# Altium schematic sheet IR&control.SchDoc(IR&control)
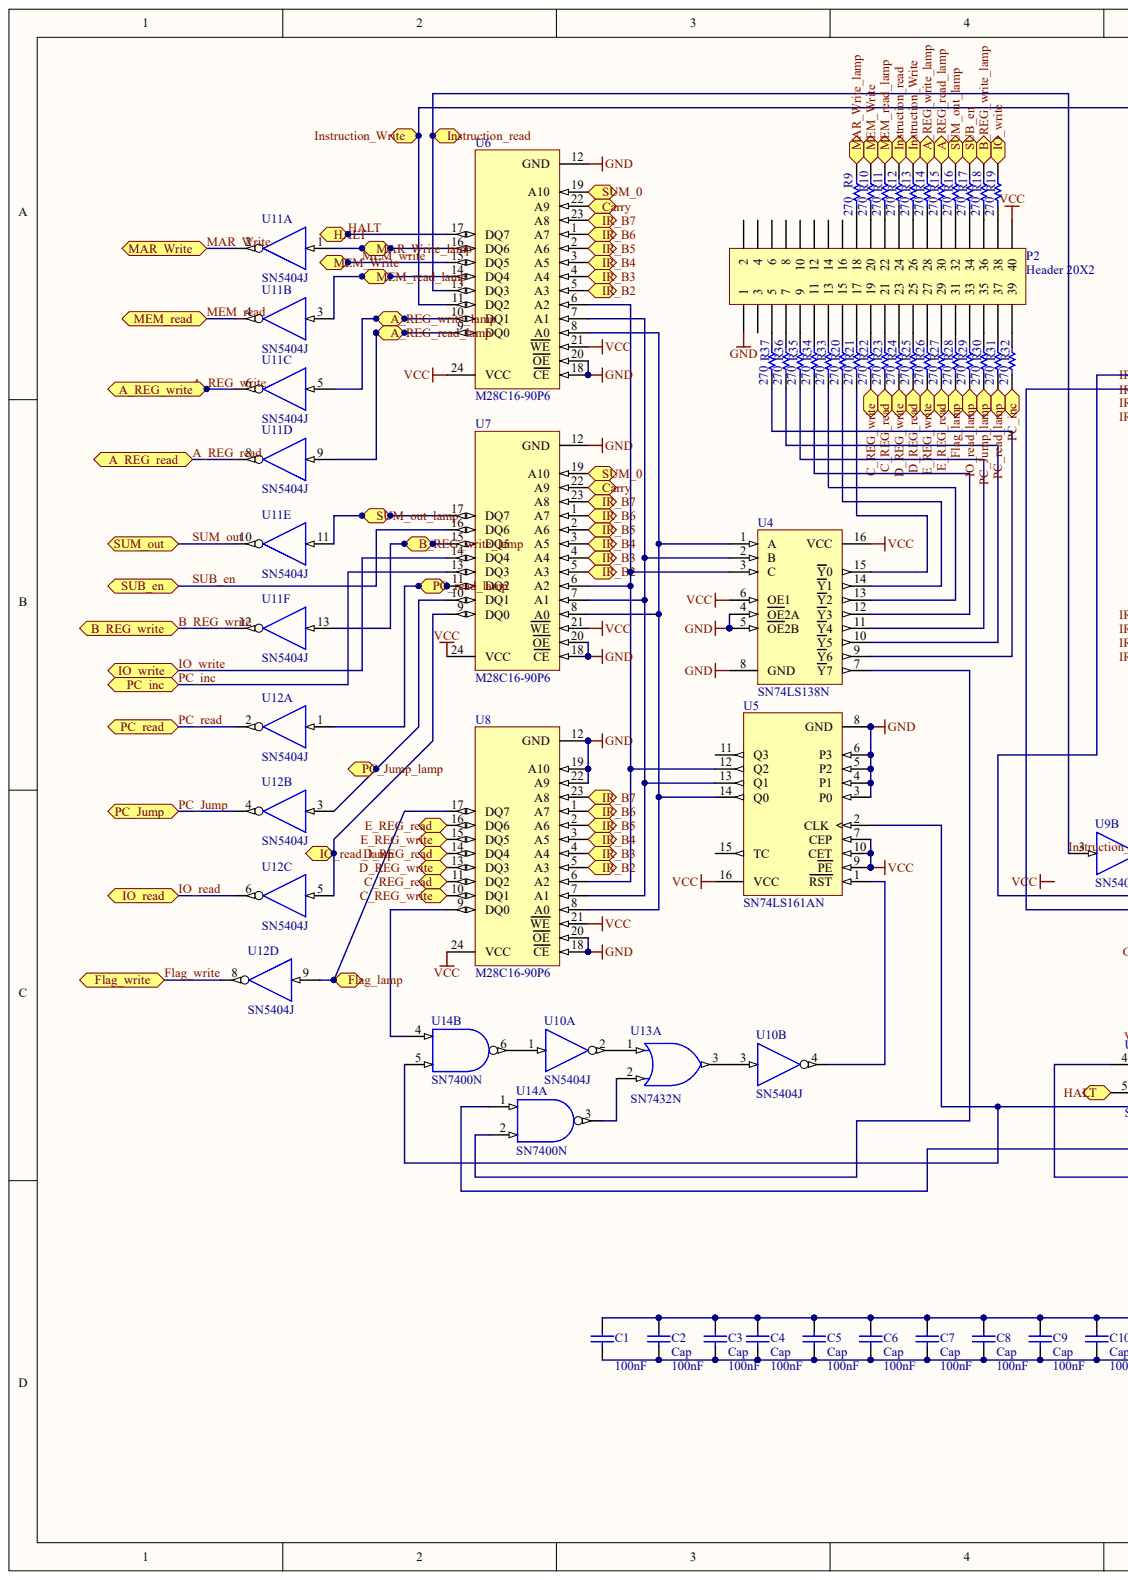
[diagram p0: IR&control.SchDoc, left]
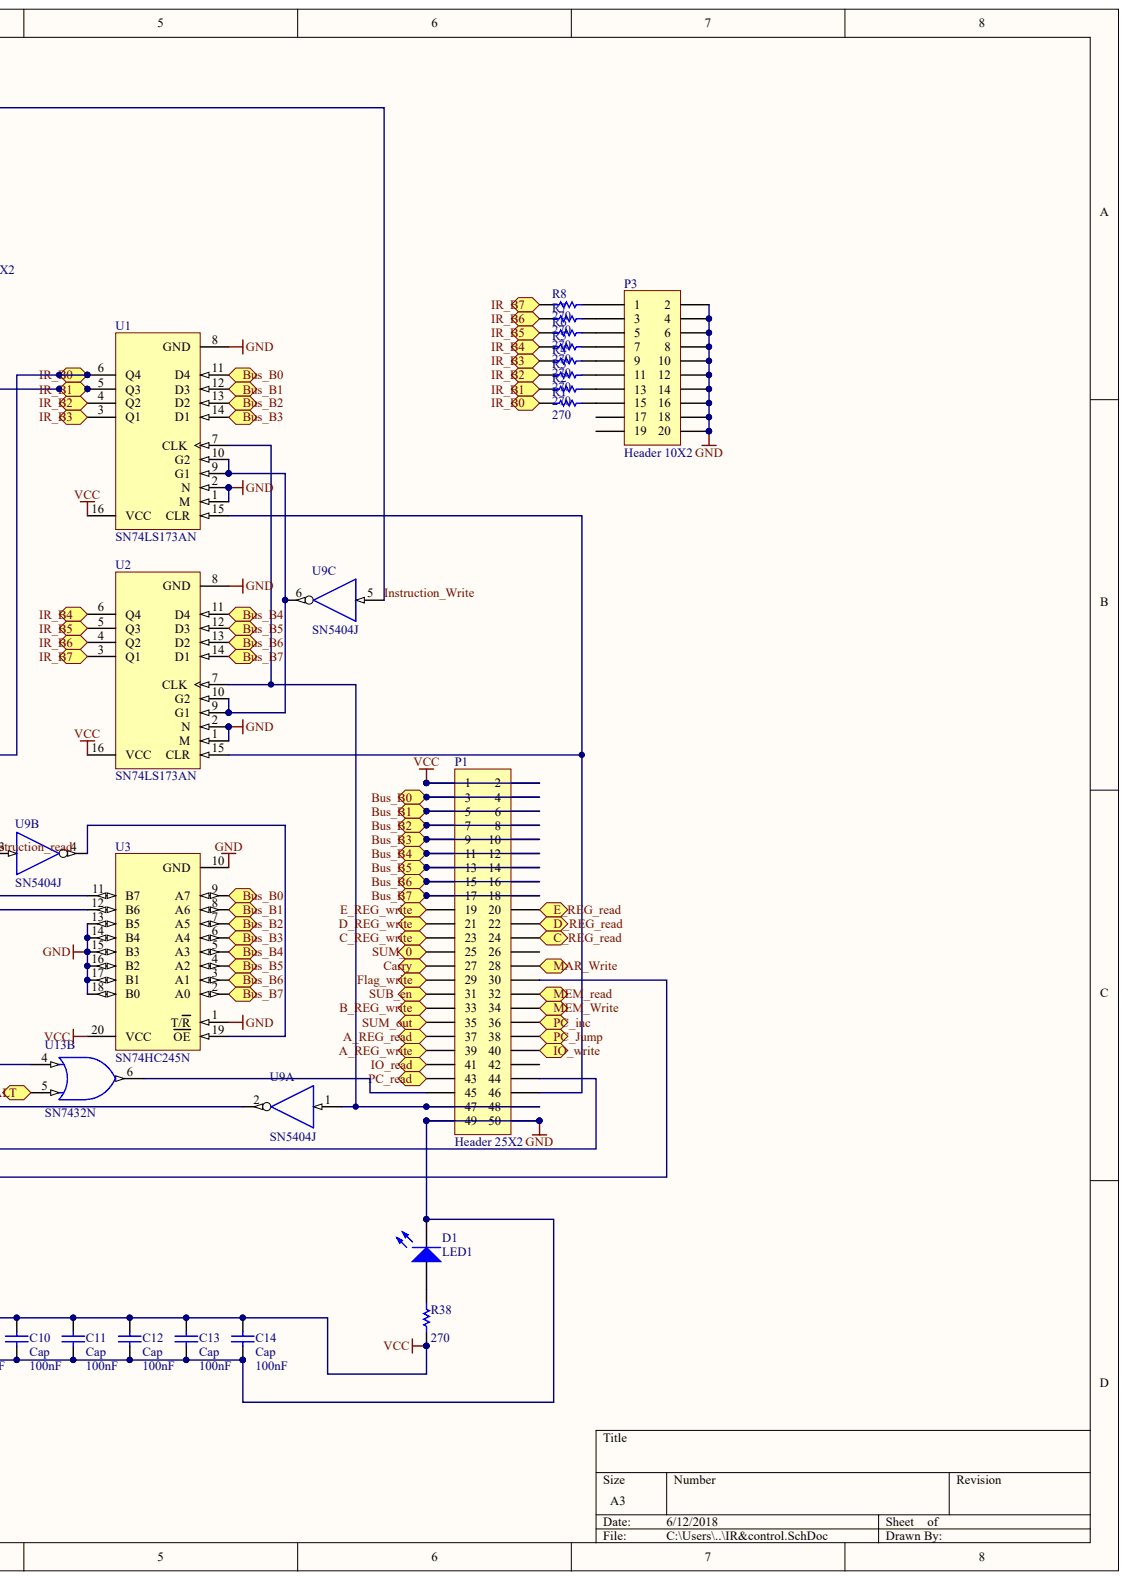
[diagram p1: IR&control.SchDoc, right]

## Parts
C1  value 100nF  (hidden comment: Cap)
C2  Cap  value 100nF
C3  Cap  value 100nF
C4  Cap  value 100nF
C5  Cap  value 100nF
C6  Cap  value 100nF
C7  Cap  value 100nF
C8  Cap  value 100nF
C9  Cap  value 100nF
C10  Cap  value 100nF
C11  Cap  value 100nF
C12  Cap  value 100nF
C13  Cap  value 100nF
C14  Cap  value 100nF
D1  LED1
P1  Header 25X2
P2  Header 20X2
P3  Header 10X2
R1  value 270  (hidden comment: Res1)
R2  value 270  (hidden comment: Res1)
R3  value 270  (hidden comment: Res1)
R4  value 270  (hidden comment: Res1)
R5  value 270  (hidden comment: Res1)
R6  value 270  (hidden comment: Res1)
R7  value 270  (hidden comment: Res1)
R8  value 270  (hidden comment: Res1)
R9  value 270  (hidden comment: Res1)
R10  value 270  (hidden comment: Res1)
R11  value 270  (hidden comment: Res1)
R12  value 270  (hidden comment: Res1)
R13  value 270  (hidden comment: Res1)
R14  value 270  (hidden comment: Res1)
R15  value 270  (hidden comment: Res1)
R16  value 270  (hidden comment: Res1)
R17  value 270  (hidden comment: Res1)
R18  value 270  (hidden comment: Res1)
R19  value 270  (hidden comment: Res1)
R20  value 270  (hidden comment: Res1)
R21  value 270  (hidden comment: Res1)
R22  value 270  (hidden comment: Res1)
R23  value 270  (hidden comment: Res1)
R24  value 270  (hidden comment: Res1)
R25  value 270  (hidden comment: Res1)
R26  value 270  (hidden comment: Res1)
R27  value 270  (hidden comment: Res1)
R28  value 270  (hidden comment: Res1)
R29  value 270  (hidden comment: Res1)
R30  value 270  (hidden comment: Res1)
R31  value 270  (hidden comment: Res1)
R32  value 270  (hidden comment: Res1)
R33  value 270  (hidden comment: Res1)
R34  value 270  (hidden comment: Res1)
R35  value 270  (hidden comment: Res1)
R36  value 270  (hidden comment: Res1)
R37  value 270  (hidden comment: Res1)
R38  value 270  (hidden comment: Res1)
U1  SN74LS173AN
U2  SN74LS173AN
U3  SN74HC245N
U4  SN74LS138N
U5  SN74LS161AN
U6  M28C16-90P6
U7  M28C16-90P6
U8  M28C16-90P6
U9  SN5404J  (parts A,B,C)
U10  SN5404J  (parts A,B)
U11  SN5404J  (parts A,B,C,D,E,F)
U12  SN5404J  (parts A,B,C,D)
U13  SN7432N  (parts A,B)
U14  SN7400N  (parts A,B)

## Ports
A_REG_READ, A_REG_READ_LAMP, A_REG_WRITE, A_REG_WRITE_LAMP, B_REG_WRITE, B_REG_WRITE_LAMP, BUS_B0, BUS_B1, BUS_B2, BUS_B3, BUS_B4, BUS_B5, BUS_B6, BUS_B7, C_REG_READ, C_REG_WRITE, CARRY, D_REG_READ, D_REG_WRITE, E_REG_READ, E_REG_WRITE, FLAG_LAMP, FLAG_WRITE, HALT, INSTRUCTION_READ, INSTRUCTION_WRITE, IO_READ, IO_READ_LAMP, IO_WRITE, IR_B0, IR_B1, IR_B2, IR_B3, IR_B4, IR_B5, IR_B6, IR_B7, MAR_WRITE, MAR_WRITE_LAMP, MEM_READ, MEM_READ_LAMP, MEM_WRITE, PC_INC, PC_JUMP, PC_JUMP_LAMP, PC_READ, PC_READ_LAMP, SUB_EN, SUM_0, SUM_OUT, SUM_OUT_LAMP

## Nets
GND: C1.1 C2.1 C3.1 C4.1 C5.1 C6.1 C7.1 C8.1 C9.1 C10.1 C11.1 C12.1 C13.1 C14.1 D1.2 P1.49 P1.50 P2.1 P3.2 P3.4 P3.6 P3.8 P3.10 P3.12 P3.14 P3.16 P3.18 P3.20 U1.1(M) U1.2(N) U1.8(GND) U2.1(M) U2.2(N) U2.8(GND) U3.1(T/R) U3.10(GND) U3.13(B5) U3.14(B4) U3.15(B3) U3.16(B2) U3.17(B1) U3.18(B0) U4.4(OE2A) U4.5(OE2B) U4.8(GND) U5.3(P0) U5.4(P1) U5.5(P2) U5.6(P3) U5.8(GND) U6.12(GND) U6.18(CE) U6.20(OE) U7.12(GND) U7.18(CE) U7.20(OE) U8.12(GND) U8.18(CE) U8.19(A10) U8.20(OE) U8.22(A9) U9.7 U10.7 U11.7 U12.7 U13.7 U14.7
VCC: C1.2 C2.2 C3.2 C4.2 C5.2 C6.2 C7.2 C8.2 C9.2 C10.2 C11.2 C12.2 C13.2 C14.2 P1.1 P1.2 P2.40 R38.1 U1.16(VCC) U2.16(VCC) U3.20(VCC) U4.6(OE1) U4.16(VCC) U5.7(CEP) U5.9(PE) U5.10(CET) U5.16(VCC) U6.21(WE) U6.24(VCC) U7.21(WE) U7.24(VCC) U8.21(WE) U8.24(VCC) U9.14 U10.14 U11.14 U12.14 U13.14 U14.14
A_REG_READ: P1.37 U11.8 ; port A_REG_READ
A_REG_WRITE: P1.39 U11.6 ; port A_REG_WRITE
B_REG_WRITE: P1.33 U11.12 ; port B_REG_WRITE
FLAG_WRITE: P1.29 U12.8 ; port FLAG_WRITE
HALT: U6.17(DQ7) U13.5 ; port HALT
INSTRUCTION_READ: R12.2 U6.13(DQ3) U9.3 ; port INSTRUCTION_READ
INSTRUCTION_WRITE: R13.2 U6.11(DQ2) U9.5 ; port INSTRUCTION_WRITE
IO_READ: P1.41 U12.6 ; port IO_READ
IO_WRITE: P1.40 R19.2 U7.14(DQ4) ; port IO_WRITE
IR_B0: R1.2 U1.6(Q4) U3.11(B7) ; port IR_B0
IR_B1: R2.2 U1.5(Q3) U3.12(B6) ; port IR_B1
IR_B2: R3.2 U1.4(Q2) U6.5(A3) U7.5(A3) U8.5(A3) ; port IR_B2
IR_B3: R4.2 U1.3(Q1) U6.4(A4) U7.4(A4) U8.4(A4) ; port IR_B3
IR_B4: R5.2 U2.6(Q4) U6.3(A5) U7.3(A5) U8.3(A5) ; port IR_B4
IR_B5: R6.2 U2.5(Q3) U6.2(A6) U7.2(A6) U8.2(A6) ; port IR_B5
IR_B6: R7.2 U2.4(Q2) U6.1(A7) U7.1(A7) U8.1(A7) ; port IR_B6
IR_B7: R8.2 U2.3(Q1) U6.23(A8) U7.23(A8) U8.23(A8) ; port IR_B7
MAR_WRITE: P1.28 U11.2 ; port MAR_WRITE
MEM_READ: P1.32 U11.4 ; port MEM_READ
MEM_WRITE: P1.34 R10.2 U6.15(DQ5) ; port MEM_WRITE
PC_INC: P1.36 R32.1 U7.13(DQ3) ; port PC_INC
PC_JUMP: P1.38 U12.4 ; port PC_JUMP
PC_READ: P1.43 U12.2 ; port PC_READ
SUB_EN: P1.31 R17.2 U7.16(DQ6) ; port SUB_EN
SUM_0: P1.25 U6.19(A10) U7.19(A10) ; port SUM_0
SUM_OUT: P1.35 U11.10 ; port SUM_OUT
NetD1_1: D1.1 R38.2
NetP1_3: P1.3 P1.4 U1.11(D4) U3.9(A7) ; port BUS_B0
NetP1_5: P1.5 P1.6 U1.12(D3) U3.8(A6) ; port BUS_B1
NetP1_7: P1.7 P1.8 U1.13(D2) U3.7(A5) ; port BUS_B2
NetP1_9: P1.9 P1.10 U1.14(D1) U3.6(A4) ; port BUS_B3
NetP1_11: P1.11 P1.12 U2.11(D4) U3.5(A3) ; port BUS_B4
NetP1_13: P1.13 P1.14 U2.12(D3) U3.4(A2) ; port BUS_B5
NetP1_15: P1.15 P1.16 U2.13(D2) U3.3(A1) ; port BUS_B6
NetP1_17: P1.17 P1.18 U2.14(D1) U3.2(A0) ; port BUS_B7
NetP1_19: P1.19 R26.1 U8.15(DQ5) ; port E_REG_WRITE
NetP1_20: P1.20 R27.1 U8.16(DQ6) ; port E_REG_READ
NetP1_21: P1.21 R24.1 U8.13(DQ3) ; port D_REG_WRITE
NetP1_22: P1.22 R25.1 U8.14(DQ4) ; port D_REG_READ
NetP1_23: P1.23 R22.1 U8.10(DQ1) ; port C_REG_WRITE
NetP1_24: P1.24 R23.1 U8.11(DQ2) ; port C_REG_READ
NetP1_27: P1.27 U6.22(A9) U7.22(A9) ; port CARRY
NetP1_30: P1.30 U13.4
NetP1_44: P1.44 U14.1
NetP1_45: P1.45 U13.6
NetP1_46: P1.46 U1.15(CLR) U2.15(CLR)
NetP1_47: P1.47 P1.48 U1.7(CLK) U2.7(CLK) U9.1
NetP2_5: P2.5 R37.2
NetP2_7: P2.7 R36.2
NetP2_9: P2.9 R35.2
NetP2_11: P2.11 R34.2
NetP2_13: P2.13 R33.2
NetP2_15: P2.15 R20.2
NetP2_17: P2.17 R21.2
NetP2_18: P2.18 R9.1
NetP2_19: P2.19 R22.2
NetP2_20: P2.20 R10.1
NetP2_21: P2.21 R23.2
NetP2_22: P2.22 R11.1
NetP2_23: P2.23 R24.2
NetP2_24: P2.24 R12.1
NetP2_25: P2.25 R25.2
NetP2_26: P2.26 R13.1
NetP2_27: P2.27 R26.2
NetP2_28: P2.28 R14.1
NetP2_29: P2.29 R27.2
NetP2_30: P2.30 R15.1
NetP2_31: P2.31 R28.2
NetP2_32: P2.32 R16.1
NetP2_33: P2.33 R29.2
NetP2_34: P2.34 R17.1
NetP2_35: P2.35 R30.2
NetP2_36: P2.36 R18.1
NetP2_37: P2.37 R31.2
NetP2_38: P2.38 R19.1
NetP2_39: P2.39 R32.2
NetP3_1: P3.1 R8.1
NetP3_3: P3.3 R7.1
NetP3_5: P3.5 R6.1
NetP3_7: P3.7 R5.1
NetP3_9: P3.9 R4.1
NetP3_11: P3.11 R3.1
NetP3_13: P3.13 R2.1
NetP3_15: P3.15 R1.1
NetR9_2: R9.2 U6.16(DQ6) U11.1 ; port MAR_WRITE_LAMP
NetR11_2: R11.2 U6.14(DQ4) U11.3 ; port MEM_READ_LAMP
NetR14_2: R14.2 U6.10(DQ1) U11.5 ; port A_REG_WRITE_LAMP
NetR15_2: R15.2 U6.9(DQ0) U11.9 ; port A_REG_READ_LAMP
NetR16_2: R16.2 U7.17(DQ7) U11.11 ; port SUM_OUT_LAMP
NetR18_2: R18.2 U7.15(DQ5) U11.13 ; port B_REG_WRITE_LAMP
NetR20_1: R20.1 U4.14(Y1)
NetR21_1: R21.1 U4.15(Y0)
NetR28_1: R28.1 U8.17(DQ7) U12.9 ; port FLAG_LAMP
NetR29_1: R29.1 U7.9(DQ0) U12.5 ; port IO_READ_LAMP
NetR30_1: R30.1 U7.10(DQ1) U12.3 ; port PC_JUMP_LAMP
NetR31_1: R31.1 U7.11(DQ2) U12.1 ; port PC_READ_LAMP
NetR33_1: R33.1 U4.13(Y2)
NetR34_1: R34.1 U4.12(Y3)
NetR35_1: R35.1 U4.11(Y4)
NetR36_1: R36.1 U4.10(Y5)
NetR37_1: R37.1 U4.9(Y6)
NetU1_9: U1.9(G1) U1.10(G2) U2.9(G1) U2.10(G2) U9.6
NetU3_19: U3.19(OE) U9.4
NetU4_1: U4.1(A) U5.14(Q0) U6.8(A0) U7.8(A0) U8.8(A0)
NetU4_2: U4.2(B) U5.13(Q1) U6.7(A1) U7.7(A1) U8.7(A1)
NetU4_3: U4.3(C) U5.12(Q2) U6.6(A2) U7.6(A2) U8.6(A2)
NetU4_7: U4.7(Y7) U14.2
NetU5_1: U5.1(RST) U10.4
NetU5_2: U5.2(CLK) U9.2 U14.5
NetU8_9: U8.9(DQ0) U14.4
NetU10_1: U10.1 U14.6
NetU10_2: U10.2 U13.1
NetU10_3: U10.3 U13.3
NetU13_2: U13.2 U14.3

Not connected: P1 26 42; P2 2 3 4 6 8 10 12 14 16; P3 17 19; U5 11(Q3) 15(TC)

## Library data of the parts
C1-C14 D1 P1-P3 R1-R38 U1-U14 = (no symbol name): Publisher: Altium Limited
  C1-C14: Published: 8-Jun-2000; LatestRevisionDate: 17-Jul-2002; LatestRevisionNote: Re-released for DXP Platform.; PackageReference: RAD-0.3; PackageDescription: Radial Cap, Thru-Hole; 2 Leads; 0.3 in Pin Spacing
  D1: Published: 8-Jun-2000; LatestRevisionDate: 08-Jul-2005; LatestRevisionNote: Mech Layer 1 removed.; PackageReference: LED-1; PackageDescription: LED; 2 Leads
  P1-P3: LatestRevisionDate: 17-Jul-2002; LatestRevisionNote: Re-released for DXP Platform.
  R1-R38: Published: 8-Jun-2000; LatestRevisionDate: 17-Jul-2002; LatestRevisionNote: Re-released for DXP Platform.; PackageReference: AXIAL-0.3; PackageDescription: Axial Device, Thru-Hole; 2 Leads; 0.3 in Pin Spacing
  U1 U2: Published: 8-Jun-2000; LatestRevisionDate: 04-Mar-2005; LatestRevisionNote: Stylized 3D Model Added.; DatasheetVersion: Mar-1988; PackageVersion: Oct-1995; PackageReference: N016D; PackageDescription: DIP; 16 Leads; Row Spacing 7.62 mm; Pitch 2.54 mm; Code_JEDEC: MS-001-BB; ComponentLink1Description: Manufacturer Link; ComponentLink1URL: http://www.ti.com/; ComponentLink2Description: Datasheet; ComponentLink2URL: http://www-s.ti.com/sc/ds/sn74ls173a.pdf
  U3: Published: 8-Jun-2000; LatestRevisionDate: 04-Mar-2005; LatestRevisionNote: Stylized 3D Model Added.; DatasheetVersion: May-1997; PackageVersion: Oct-1995; PackageReference: N020; PackageDescription: DIP; 20 Leads; Row Spacing 7.62 mm; Pitch 2.54 mm; ComponentLink1Description: Manufacturer Link; ComponentLink1URL: http://www.ti.com/; ComponentLink2Description: Datasheet; ComponentLink2URL: http://www-s.ti.com/sc/ds/sn74hc245.pdf
  U4: Published: 8-Jun-2000; LatestRevisionDate: 04-Mar-2005; LatestRevisionNote: Stylized 3D Model Added.; DatasheetVersion: Mar-1988; PackageVersion: Oct-1995; PackageReference: N016; PackageDescription: DIP; 16 Leads; Row Spacing 7.62 mm; Pitch 2.54 mm; Code_JEDEC: MS-001-BB; ComponentLink1Description: Manufacturer Link; ComponentLink1URL: http://www.ti.com/; ComponentLink2Description: Datasheet; ComponentLink2URL: http://www-s.ti.com/sc/ds/sn74ls138.pdf
  U5: Published: 8-Jun-2000; LatestRevisionDate: 04-Mar-2005; LatestRevisionNote: Stylized 3D Model Added.; DatasheetVersion: Mar-1988; PackageVersion: Oct-1995; PackageReference: N016; PackageDescription: DIP; 16 Leads; Row Spacing 7.62 mm; Pitch 2.54 mm; Code_JEDEC: MS-001-BB; ComponentLink1Description: Manufacturer Link; ComponentLink1URL: http://www.ti.com/; ComponentLink2Description: Datasheet; ComponentLink2URL: http://www-s.ti.com/sc/ds/sn74ls161a.pdf; ComponentLink3Description: URL Link1; ComponentLink3URL: http://focus.ti.com/docs/prod/folders/print/sn74ls161a.html
  U6-U8: Published: 24-Aug-2001; LatestRevisionDate: 06-Jun-2005; LatestRevisionNote: Stylized 3D Model Added.; DatasheetVersion: Nov-1997; PackageReference: PDIP24; PackageDescription: DIP; 24 Leads; Row Spacing 15.24 mm; Pitch 2.54 mm; ComponentLink1Description: Manufacturer Link; ComponentLink1URL: http://www.st.com/
  U9-U12: Published: 8-Jun-2000; LatestRevisionDate: 04-Mar-2005; LatestRevisionNote: Stylized 3D Model Added.; DatasheetVersion: Mar-1988; PackageVersion: Jun-1999; PackageReference: J014; PackageDescription: DIP; 14 Leads; Row Spacing 7.62 mm; Pitch 2.54 mm; ComponentLink1Description: Manufacturer Link; ComponentLink1URL: http://www.ti.com/; ComponentLink2Description: Datasheet; ComponentLink2URL: http://www-s.ti.com/sc/ds/sn5404.pdf; ComponentLink3Description: Packaging Specification; ComponentLink3URL: http://focus.ti.com/lit/ml/mcer002d/mcer002d.pdf
  U13: Published: 8-Jun-2000; LatestRevisionDate: 04-Mar-2005; LatestRevisionNote: Stylized 3D Model Added.; DatasheetVersion: Mar-1988; PackageVersion: Oct-1995; PackageReference: N014; PackageDescription: DIP; 14 Leads; Row Spacing 7.62 mm; Pitch 2.54 mm; ComponentLink1Description: Manufacturer Link; ComponentLink1URL: http://www.ti.com/; ComponentLink2Description: Datasheet; ComponentLink2URL: http://www-s.ti.com/sc/ds/sn54ls32.pdf; ComponentLink3Description: Packaging Specification; ComponentLink3URL: http://focus.ti.com/lit/ml/mpdi002c/mpdi002c.pdf
  U14: Published: 8-Jun-2000; LatestRevisionDate: 04-Mar-2005; LatestRevisionNote: Stylized 3D Model Added.; DatasheetVersion: Mar-1988; PackageVersion: Oct-1995; PackageReference: N014; PackageDescription: DIP; 14 Leads; Row Spacing 7.62 mm; Pitch 2.54 mm; ComponentLink1Description: Manufacturer Link; ComponentLink1URL: http://www.ti.com/; ComponentLink2Description: Datasheet; ComponentLink2URL: http://www-s.ti.com/sc/ds/sn5400.pdf; ComponentLink3Description: Packaging Specification; ComponentLink3URL: http://focus.ti.com/lit/ml/mpdi002c/mpdi002c.pdf
